# Altium schematic sheet Inrus.SchDoc(Inrus)
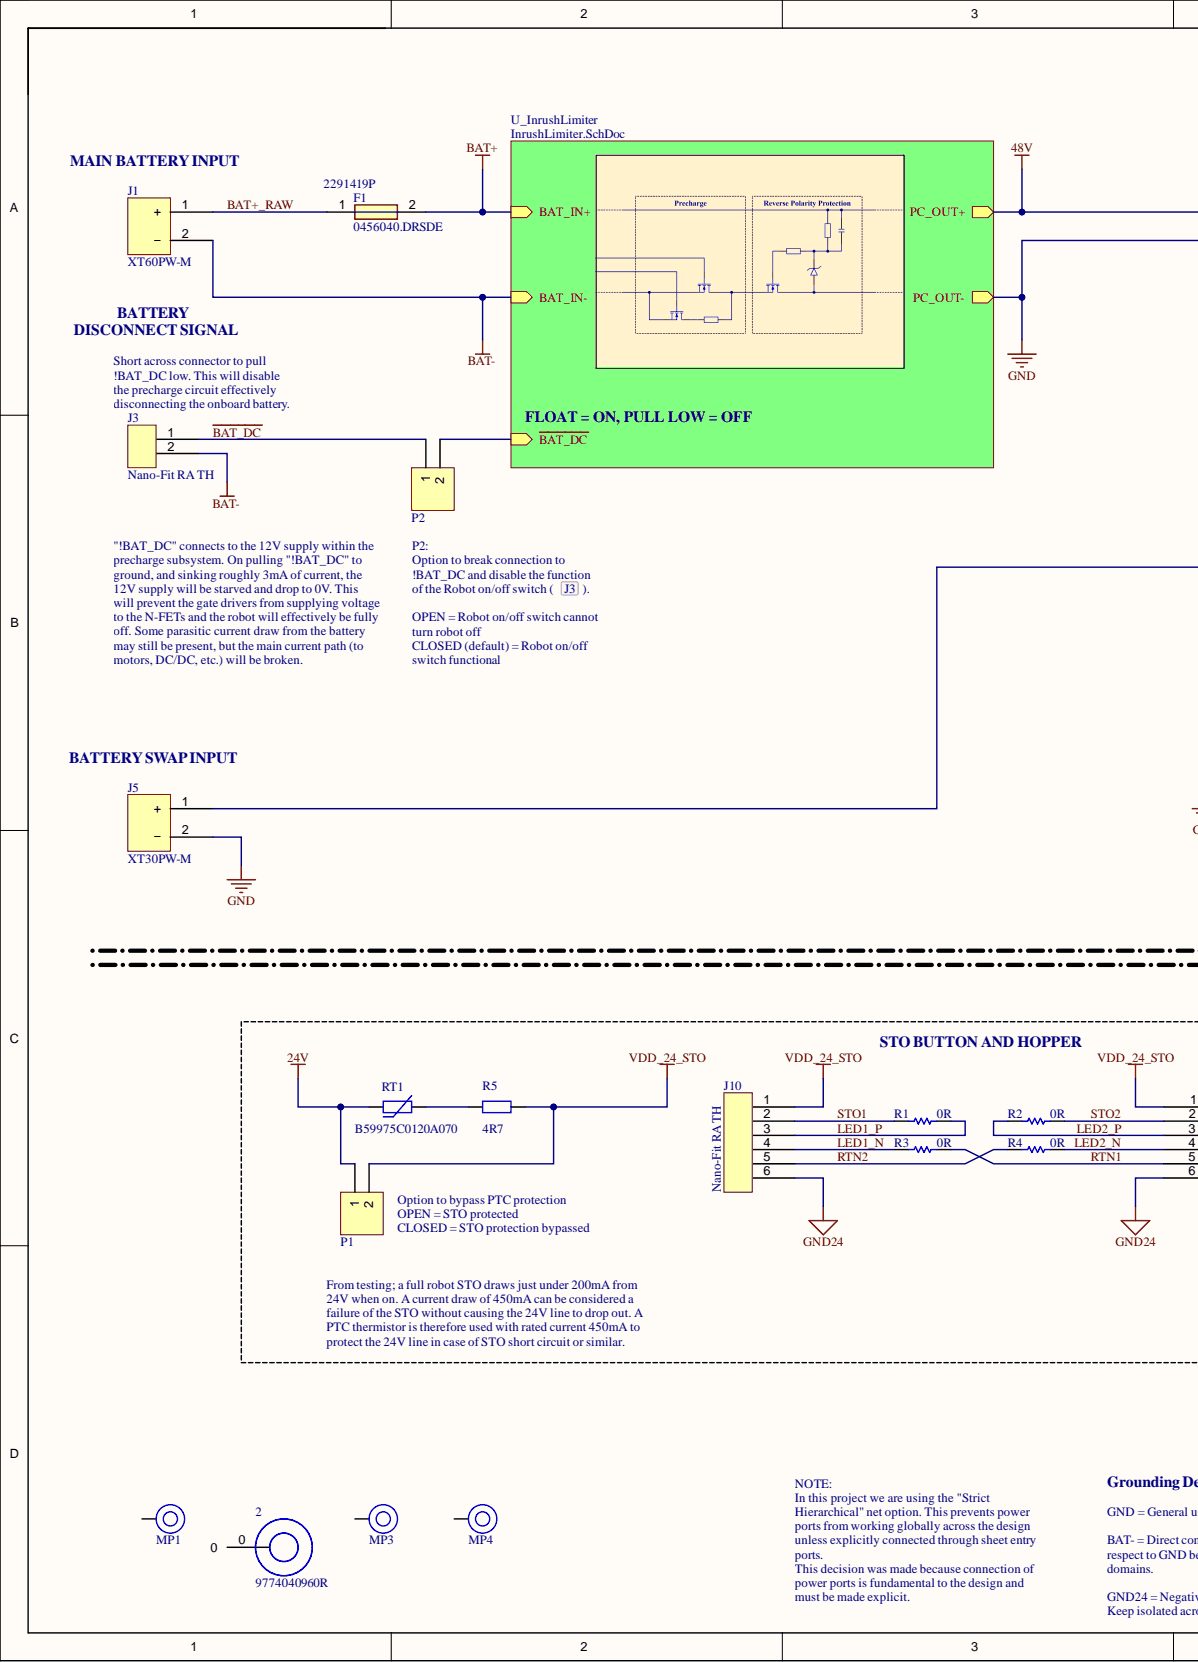
[diagram p0: Inrus.SchDoc, left]
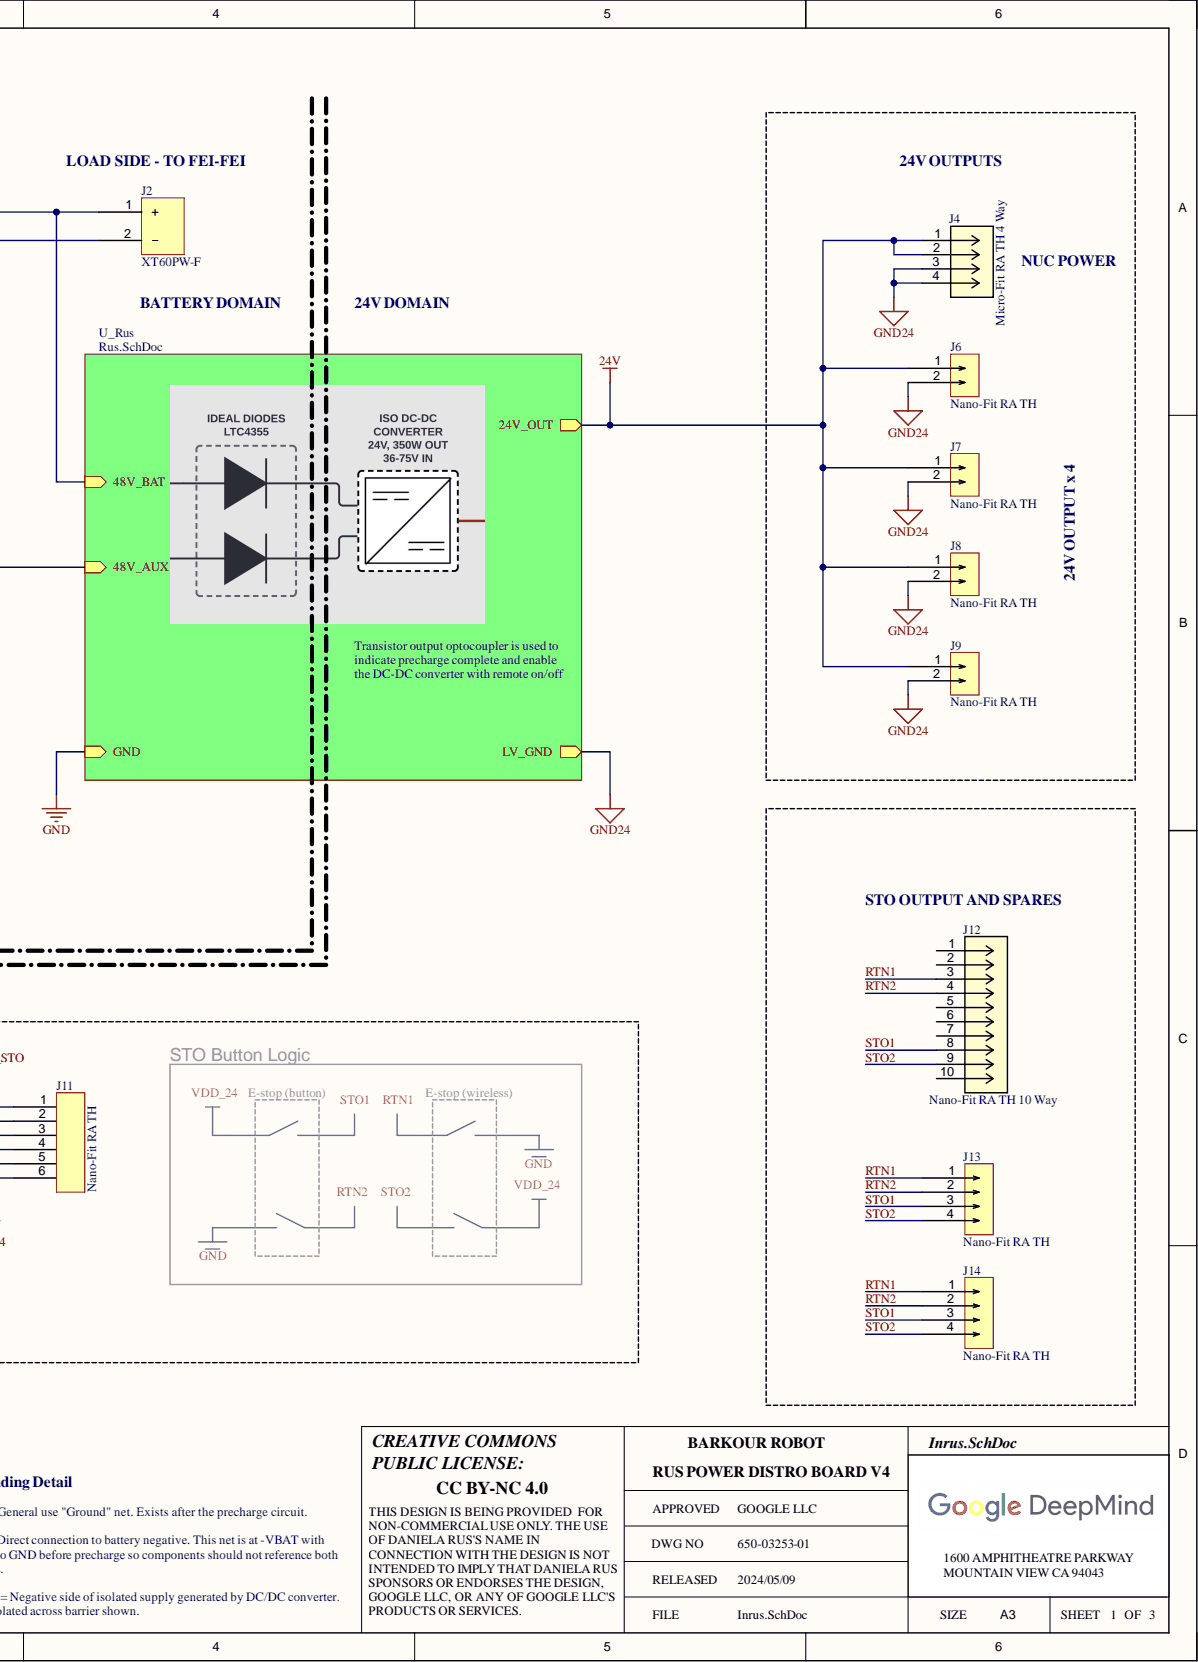
[diagram p1: Inrus.SchDoc, right]

## Parts
2  9774040960R  [9774040960R]
F1  0456040.DRSDE  Supplier Part Number 1: 2291419P  [FUSC12245X450N]
J1  XT60PW-M  [XT60PW_M]
J2  XT60PW-F  [XT60PW_F]
J3  Colloquial: Nano-Fit RA TH  [MOLEX 1053131102]  (hidden comment: 105313-1202)
J4  Colloquial: Micro-Fit RA TH 4 Way  [MOLEX 430450401]  (hidden comment: 430450401)
J5  XT30PW-M  [XT30PW_M]
J6  105313-1202  [MOLEX 1053131102]  (value not drawn)
J7  105313-1202  [MOLEX 1053131102]  (value not drawn)
J8  105313-1202  [MOLEX 1053131102]  (value not drawn)
J9  105313-1202  [MOLEX 1053131102]  (value not drawn)
J10  Colloquial: Nano-Fit RA TH  [MOLEX 1053141206]  (hidden comment: 105314-1206)
J11  Colloquial: Nano-Fit RA TH  [MOLEX 1053141206]  (hidden comment: 105314-1206)
J12  Colloquial: Nano-Fit RA TH 10 Way  [MOLEX 1053141110]  (hidden comment: 1053141310)
J13  105314-1204  [MOLEX 1053141204]  (value not drawn)
J14  105314-1204  [MOLEX 1053141204]  (value not drawn)
MP1  M3 x 4mm  [9774040360]  (value not drawn)
MP3  M3 x 4mm  [9774040360]  (value not drawn)
MP4  M3 x 4mm  [9774040360]  (value not drawn)
P1  Header 2  [HDR1X2]  (value not drawn)
P2  Header 2  [HDR1X2]  (value not drawn)
R1  Resistance: 0R  [RESC1608X55X25ML10T15]  (hidden comment: RC0603JR-070RL)
R2  Resistance: 0R  [RESC1608X55X25ML10T15]  (hidden comment: RC0603JR-070RL)
R3  Resistance: 0R  [RESC1608X55X25ML10T15]  (hidden comment: RC0603JR-070RL)
R4  Resistance: 0R  [RESC1608X55X25ML10T15]  (hidden comment: RC0603JR-070RL)
R5  4R7  [RES 2512_6432]
RT1  B59975C0120A070  [RES THERM TH 9.0x12.5 DISC LEADED]

## Nets
24V: J4.1 J4.2 J6.1 J7.1 J8.1 J9.1 P1.1 RT1.1
48V: J2.1
BAT+: F1.2
BAT-: J1.2 J3.2
GND: J2.2 J5.2
GND24: J4.3 J4.4 J6.2 J7.2 J8.2 J9.2 J10.6 J11.6
VDD_24_STO: J10.1 J11.1 P1.2 R5.2
~{BAT_DC}: J3.1 P2.1
BAT+_RAW: F1.1 J1.1
LED1_N: J10.4 R3.2
LED1_P: J10.3 R1.1
LED2_N: J11.4 R4.2
LED2_P: J11.3 R2.1
RTN1: J11.5 J12.3 J13.1 J14.1 R3.1
RTN2: J10.5 J12.4 J13.2 J14.2 R4.1
STO1: J10.2 J12.8 J13.3 J14.3 R1.2
STO2: J11.2 J12.9 J13.4 J14.4 R2.2
Net2_0: 2.0
NetJ5_1: J5.1
NetJ12_1: J12.1
NetJ12_2: J12.2
NetJ12_5: J12.5
NetJ12_6: J12.6
NetJ12_7: J12.7
NetJ12_10: J12.10
NetMP1_1: MP1.1
NetMP3_1: MP3.1
NetMP4_1: MP4.1
NetP2_2: P2.2
NetR5_1: R5.1 RT1.2

## Library data of the parts
2 = 9774040960R: Spacer Material: Steel Mounting: SMT Series: WA-SMST For ø: 3.3 mm; Manufacturer: Wurth Electronics; Inside Diameter: 0.13inch; Outside Diameter: 0.236inch; Plating: Tin; Threaded/Unthreaded: Unthreaded; Category: Spacers, Standoffs; Component Kind: Standard; ComponentLink1Description: http://www.farnell.com/datasheets/1960394.pdf?src-supplier=Farnell; ComponentLink1URL: http://www.farnell.com/datasheets/1960394.pdf?src-supplier=Farnell; ComponentLink2Description: http://www.mouser.com/ds/2/445/9774040960R-611108.pdf?src-supplier=Mouser; ComponentLink2URL: http://www.mouser.com/ds/2/445/9774040960R-611108.pdf?src-supplier=Mouser; ComponentLink3Description: https://media.digikey.com/pdf/Data%20Sheets/Wurth%20Electronics%20PDFs/WA-SMSx_Performance_Flyer.pdf?src-supplier=Digi-Key; ComponentLink3URL: https://media.digikey.com/pdf/Data%20Sheets/Wurth%20Electronics%20PDFs/WA-SMSx_Performance_Flyer.pdf?src-supplier=Digi-Key; ComponentLink4Description: http://www.farnell.com/datasheets/1960394.pdf?src-supplier=Element14; ComponentLink4URL: http://www.farnell.com/datasheets/1960394.pdf?src-supplier=Element14; ComponentLink5Description: http://www.farnell.com/datasheets/1960394.pdf?src-supplier=Newark; ComponentLink5URL: http://www.farnell.com/datasheets/1960394.pdf?src-supplier=Newark; Length: 0.213inch; Library Name: Schlib1.SchLib; Manufacturer Part Number: 9774040960R; Material: Steel; Pricing 1: 1=1.79000, 10=1.71600, 50=1.50700, 100=1.40200 (USD); REACH SVHC: Unknown; RoHS: Yes; Stock 1: 190; Supplier 1: Digi-Key; Supplier Part Number 1: 732-7092-1-ND
F1 = 0456040.DRSDE: Electric Fuse, Fast Blow, 40A, 250VAC, 80VDC, 150A (IR), Surface Mount, NANO; Manufacturer: Littelfuse; Category: Fuses; Component Kind: Standard; Datasheet Link: https://www.littelfuse.com/~/media/electronics/datasheets/fuses/littelfuse_fuse_456sde_datasheet.pdf.pdf; Height: 4.5mm; Manufacturer Part Number: 0456040.DRSDE; Manufacturer_Name: LITTELFUSE; Manufacturer_Part_Number: 0456040.DRSDE; Mouser Part Number: 576-0456040.DRSDE; Mouser Price/Stock: https://www.mouser.co.uk/ProductDetail/Littelfuse/0456040.DRSDE?qs=iLbezkQI%252Bsg1J2n4oyxfKg%3D%3D; Pricing 1: 50=3.055, 100=2.55, 250=2.475, 500=2.115 (GBP); RoHS: Yes; Stock 1: 1372; Supplier 1: Rs
J1 = XT60PW-M: Amass XT60 RA TH male header; Component Kind: Standard; Manufacturer: Amass; Manufacturer Part Number: XT60PW-M; Library Name: DMR_common_lib.SchLib
J2 = XT60PW-F: Amass XT60 RA TH female header; Component Kind: Standard; Manufacturer: Amass; Manufacturer Part Number: XT60PW-F; Library Name: DMR_common_lib.SchLib
J3 J6-J9 = Nano-Fit RA TH 2 Way Header: Nano-Fit Right Angle Header; Through Hole; 2.50mm; Single Row; 2 Circuit; Gold Plated; Manufacturer: Molex; Gender: Male; Category: Headers and Wire Housings; Color: Black; Component Kind: Standard; Contact Material: Brass; Contact Plating: Gold; Manufacturer Part Number: 105313-1202; Mount: Through Hole; Number of Rows: 1; Pricing 1: 10=1.38000 (GBP); REACH SVHC: Unknown; RoHS: Yes; RoHS Compliant: Yes; Stock 1: 621; Supplier 1: RSComponents; Supplier Part Number 1: 1225087; Termination: Through Hole; Library Name: DMR_common_lib.SchLib
  J6-J9: Colloquial: Nano-Fit RA TH
J4 = Micro-Fit RA TH 4 Way Header Dual Row: Header, 2 Row, Right-Angle, Snap-In Lock, 4 Pin, Gold Plate, SMT/Glow Wire Compatible; Manufacturer: Molex; Category: Headers and Wire Housings; Component Kind: Standard; ComponentLink1Description: https://datasheet.ciiva.com/5153/0430450401-pcb-headers-142810-5153780.pdf?src-supplier=Mouser; ComponentLink1URL: https://datasheet.ciiva.com/5153/0430450401-pcb-headers-142810-5153780.pdf?src-supplier=Mouser; ComponentLink2Description: https://datasheet.ciiva.com/31838/151680430450401-pcb-headers-31838471.pdf?src-supplier=Onlinecomponents.com; ComponentLink2URL: https://datasheet.ciiva.com/31838/151680430450401-pcb-headers-31838471.pdf?src-supplier=Onlinecomponents.com; ComponentLink3Description: https://datasheet.ciiva.com/11749/0900766b80d483ee-11749369.pdf?src-supplier=RSComponents; ComponentLink3URL: https://datasheet.ciiva.com/11749/0900766b80d483ee-11749369.pdf?src-supplier=RSComponents; ComponentLink4Description: https://datasheet.ciiva.com/10090/0430450401-pcb-headers-10090512.pdf?src-supplier=Avnet; ComponentLink4URL: https://datasheet.ciiva.com/10090/0430450401-pcb-headers-10090512.pdf?src-supplier=Avnet; ComponentLink5Description: https://datasheet.ciiva.com/1443/1780486-1443445.pdf?src-supplier=Element14; ComponentLink5URL: https://datasheet.ciiva.com/1443/1780486-1443445.pdf?src-supplier=Element14; Manufacturer Part Number: 430450401; Pricing 1: 5=1.54600, 100=1.21000, 375=1.08600, 1500=0.86200, 3000=0.80000 (GBP); RefDes: RefDes; RoHS: Yes; Stock 1: 2315; Supplier 1: RSComponents; Supplier Part Number 1: 6700321; Type: DEV; Copyright: Copyright (C) 2022 Ultra Librarian. All rights reserved.; Library Name: DMR_common_lib.SchLib
J5 = XT30PW-M: Amass XT30 RA TH male header; Component Kind: Standard; Manufacturer: Amass; Manufacturer Part Number: XT30PW-M; Library Name: DMR_common_lib.SchLib
J10 J11 = Nano-Fit RA TH 6 Way Header Dual Row: Nano-Fit Right Angle Header; Through Hole; 2.50mm; Dual Row; 6 Circuit; Gold Plated; Manufacturer: Molex; Gender: Male; Category: Headers and Wire Housings; Color: Black; Component Kind: Standard; Contact Material: Brass; Contact Plating: Gold; Manufacturer Part Number: 105314-1206; Mount: Through Hole; Number of Rows: 2; Pricing 1: 10=1.38000 (GBP); REACH SVHC: Unknown; RoHS: Yes; RoHS Compliant: Yes; Stock 1: 621; Supplier 1: Farnell; Supplier Part Number 1: 2576871; Termination: Through Hole; Library Name: DMR_common_lib.SchLib
J12 = Nano-Fit RA TH 10 Way Header: Nano-Fit Right Angle Header; Through Hole; 2.50mm; Dual Row; 10 Circuit; Gold Plating; Manufacturer: Molex; Component Kind: Standard; Manufacturer Part Number: 1053141310; RefDes: RefDes; Type: DEV; Copyright: Copyright (C) 2022 Ultra Librarian. All rights reserved.; Library Name: DMR_common_lib.SchLib
J13 J14 = Nano-Fit RA TH 4 Way Header Dual Row: Nano-Fit Right Angle Header; Through Hole; 2.50mm; Dual Row; 4 Circuit; Gold Plated; Colloquial: Nano-Fit RA TH; Component Kind: Standard; Manufacturer Part Number: 105314-1204; Library Name: DMR_common_lib.SchLib
MP1 MP3 MP4 = 9774040360R: WA-SMSI SMT Steel Spacer with internal Thread, OD 6mm, M3 x 4mm; Manufacturer: Wurth Elektronik; Inside Diameter: 4.2mm; Surface: Tin; Category: SMT Spacers; Component Kind: Standard; ComponentLink1Description: Website Link; ComponentLink1URL: https://www.we-online.com/redexpert/article/9774040360R?ad; ComponentLink2Description: Datasheet Link; ComponentLink2URL: https://www.we-online.com/redexpert/spec/9774040360R?ad; Height: 4mm; Library Name: WA-SMSI.SchLib; Manufacturer Part Number: 9774040360R; Match Code: WA-SMSI; Material: Steel; Mount: Surface Mount; Operating Temperature Max: 150°C; Operating Temperature Min: -55°C; Outside Diameter: 6mm; Packaging: Tape and Reel; Thread Size: M3
P1 P2 = Header 2: Header, 2-Pin; Component Kind: Standard; LatestRevisionDate: 17-Jul-2002; LatestRevisionNote: Re-released for DXP Platform.; Library Name: Miscellaneous Connectors.IntLib; Signal Integrity: Connector; Publisher: Altium Limited
R1-R4 = CMP-2100-03667-1: Chip Resistor, 0 Ohm, +/- 5%, 0.1 W, -55 to 155 degC, 0603 (1608 Metric), RoHS, Tape and Reel; Manufacturer: Yageo; Power Rating: 100mW; Temperature Coefficient: 200ppm/degreesC; Voltage Rating: 50V; Case Code (Imperial): 0603; Case Code (Metric): 1608; Case/Package: 0603; Component Kind: Standard; Composition: ThickFilm; Contact Plating: Tin; Datasheet URL: http://www.yageo.com/NewPortal/yageodocoutput?fileName=/pdf/R-Chip/PYu-RC_Group_51_RoHS_L_5.pdf; Datasheet Version: Rev. 5, 10/2016; Depth: 0.8mm; Height: 0.45mm; Lead Free: LeadFree; Length: 1.6mm; Library Name: Altium Content Vault; Manufacturer URL: http://www.yageo.com/; Max Operating Temperature: 155degC; Military Standard: Not; Min Operating Temperature: -55degC; Number of Pins: 2; Package Description: 2-Pin Surface Mount Device, Body 1.6 x 0.8 mm; Package Quantity: 5000; Package Reference: 0603; Packaging: TapeandReel; Radiation Hardening: No; REACH SVHC: NoSVHC; RoHSCompliant: true; Termination: SMD/SMT; Tolerance: 5%; Voltage Rating (DC): 50V; Width: 0.8mm
R5 = R: Generic Resistor; Power Rating: 1000mW; Temperature Coefficient: 100ppm/°C; Tolerance: 1%; Voltage Rating: 200V; Component Kind: Standard; Resistance: 4R7; Size Code: 2512; Library Name: DMR_common_lib.SchLib
RT1 = B59975C0120A070: Thermistor PTC 1.8 Ohm 25% 2-Pin Radial Cardboard Strip; Manufacturer: Epcos; Lead Length: 25mm; Max Supply Voltage: 30V; Case/Package: Radial; Category: PTC Resettable Fuses; Component Kind: Standard; ComponentLink1Description: https://datasheet.ciiva.com/15097/getdatasheetpartid-382302-15097925.pdf?src-supplier=Verical; ComponentLink1URL: https://datasheet.ciiva.com/15097/getdatasheetpartid-382302-15097925.pdf?src-supplier=Verical; ComponentLink2Description: https://datasheet.ciiva.com/48779/1899685-48779500.pdf?src-supplier=Element14; ComponentLink2URL: https://datasheet.ciiva.com/48779/1899685-48779500.pdf?src-supplier=Element14; Contact Plating: Tin; Depth: 3.5mm; Height: 12.5mm; Lead Free: Lead Free; Manufacturer Part Number: B59975C0120A070; Max Operating Temperature: 125°C; Min Operating Temperature: -40°C; Mount: Through Hole; Number of Pins: 2; Operating Supply Voltage: 30V; Packaging: Strip; Pricing 1: 1=1.56000, 10=1.23000, 50=0.92700, 100=0.83300, 200=0.72800, 500=0.62200, 2500=0.61000 (GBP); Radiation Hardening: No; REACH SVHC: No SVHC; RoHS: Yes; RoHS Compliant: Yes; Stock 1: 1677; Supplier 1: Farnell; Supplier Part Number 1: 9753087; Thickness: 3.5mm; Tolerance: 25%; Width: 9mm; Library Name: DMR_common_lib.SchLib
